# Altium schematic sheet MAIN_SHEET.SchDoc
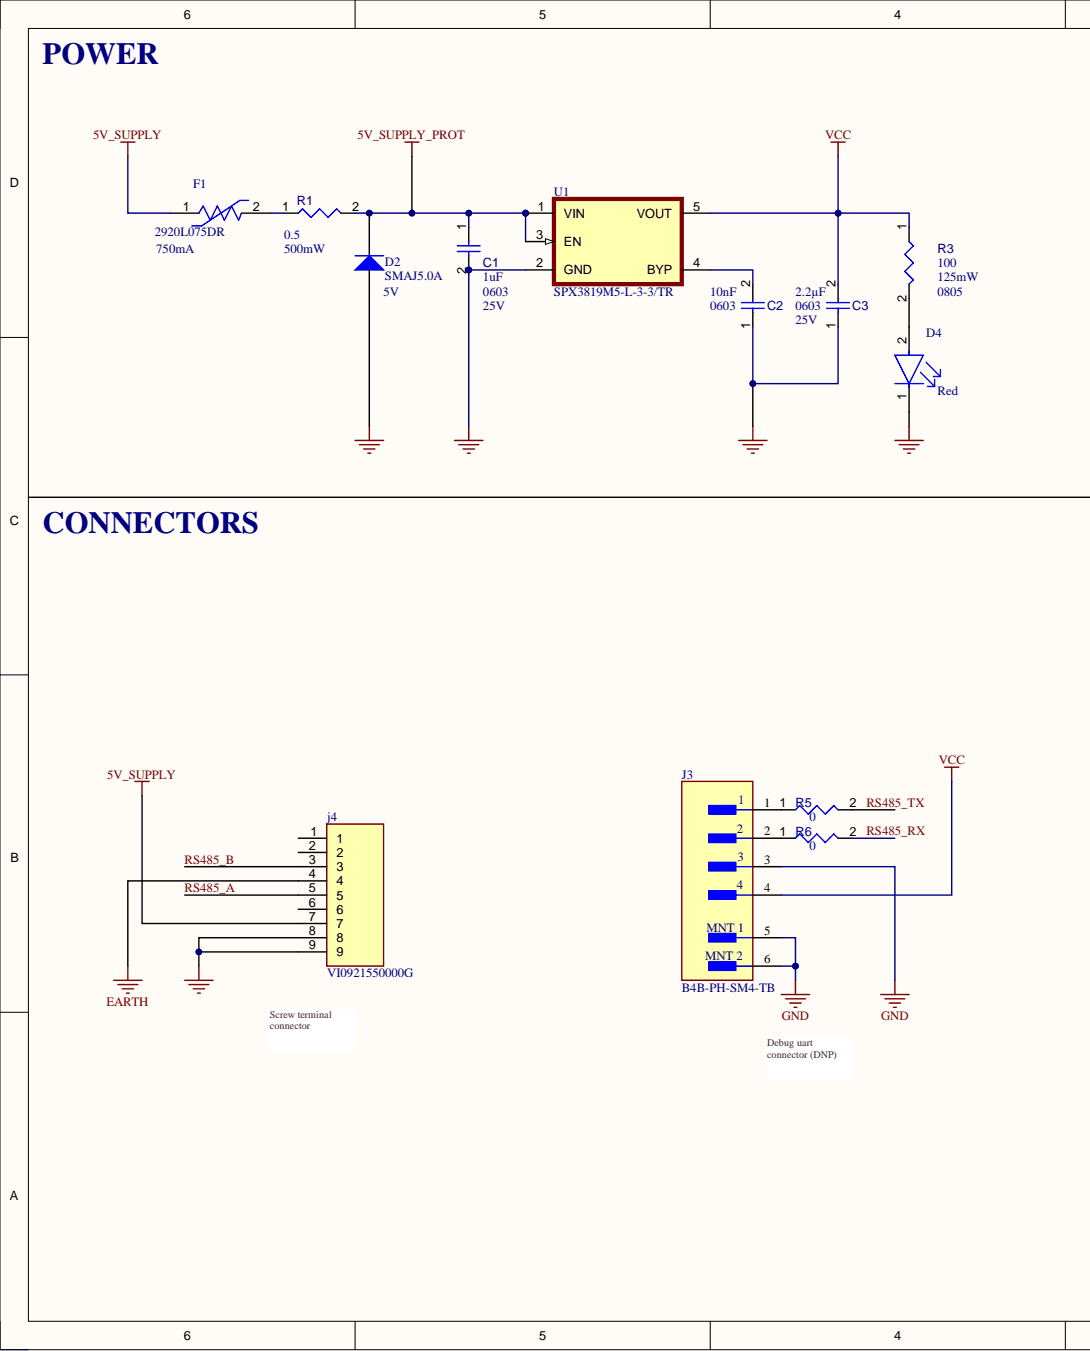
[diagram p0: MAIN_SHEET.SchDoc, left]
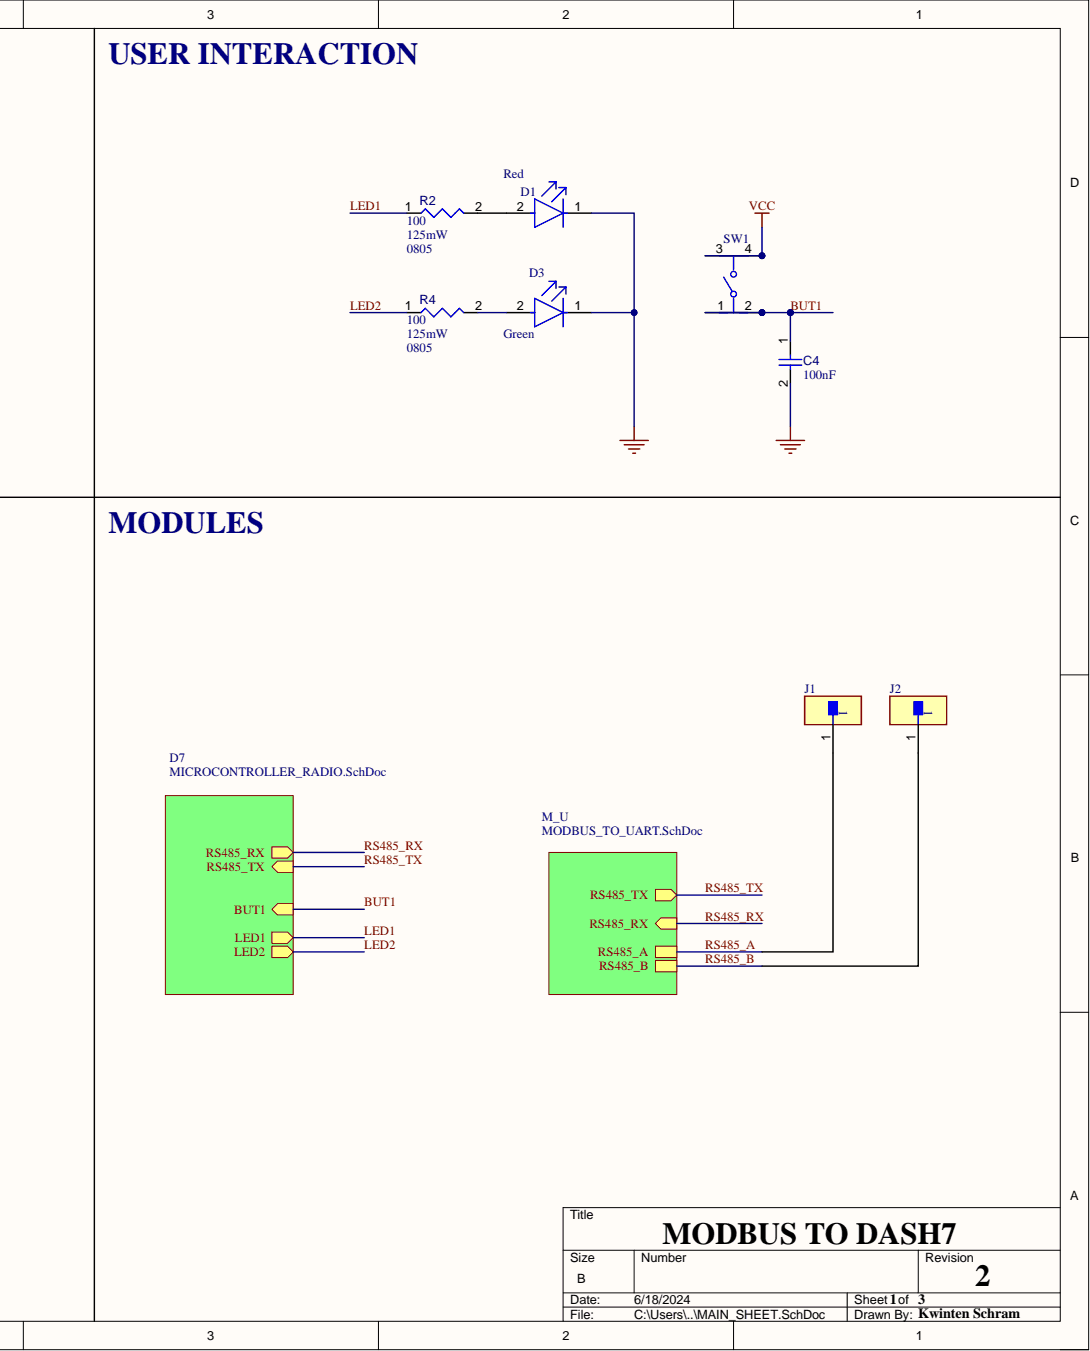
[diagram p1: MAIN_SHEET.SchDoc, right]

## Parts
C1  Capacitance: 1uF  Case/Package: 0603  Rated DC Voltage (URdc): 25V  [FP-CC0603-DA-MFG]  (hidden comment: CC0603KPX7R8BB105)
C2  value 10nF  Case/Package: 0603  [FP-C0603C-CF-IPC_B]  (hidden comment: C0603C103K2RACTU)
C3  value 2.2µF  Case/Package: 0603  Rated DC Voltage (URdc): 25V  [FP-GRM188-0_2-IPC_C]  (hidden comment: GRM188Z71E225KE43D)
C4  value 100nF  [FP-0603-L_1_6_0_15-W_0_81-MFG]  (hidden comment: 06033C104KAT2A)
D1  Color: Red  [FP-APT1608EC-MFG]  (hidden comment: APT1608SURCK)
D2  SMAJ5.0A  Forward Voltage: 5V  [BOUR-SMA-2_V]
D3  Color: Green  [FP-APG1608CGKC-T-MFG]  (hidden comment: APG1608CGKC/T)
D4  Color: Red  [FP-APT1608EC-MFG]  (hidden comment: APT1608SURCK)
F1  2920L075DR  Current Rating: 750mA  [FP-2920L050DR-MFG]
J1  PBC01SAAN  [FP-PBC01SAAN-MFG]  (value not drawn)
J2  PBC01SAAN  [FP-PBC01SAAN-MFG]  (value not drawn)
J3  B4B-PH-SM4-TB  [FP-B4B-PH-SM4-TB-MFG]
j4  VI0921550000G  [VI0921550000G-Footprint-1]
R1  value 0.5  Power: 500mW  [RESC3126X65X50NL10T20]  (hidden comment: RL1210FR-070R5L)
R2  value 100  Case/Package: 0805  Power: 125mW  [FP-AC0805-IPC_A]  (hidden comment: AC0805FR-07100RL)
R3  value 100  Case/Package: 0805  Power: 125mW  [FP-AC0805-IPC_A]  (hidden comment: AC0805FR-07100RL)
R4  value 100  Case/Package: 0805  Power: 125mW  [FP-AC0805-IPC_A]  (hidden comment: AC0805FR-07100RL)
R5  value 0  [FP-CRCW0402-e3-IPC_A]  (hidden comment: CRCW04020000Z0ED)
R6  value 0  [FP-CRCW0402-e3-IPC_A]  (hidden comment: CRCW04020000Z0ED)
SW1  PTS526SK15SMTR2LFS  [FP-PTS526SK15SMTR2LFS-MFG]  (value not drawn)
U1  SPX3819M5-L-3-3/TR  [SOT95P280X145-5N]

## Nets
5V_SUPPLY: F1.1 j4.7
5V_SUPPLY_PROT: C1.1 D2.2 R1.2 U1.1(VIN) U1.3(EN)
EARTH: j4.4
GND: C1.2 C2.1 C3.1 C4.2 D1.1 D2.1 D3.1 D4.1 J3.3 J3.5 J3.6 j4.8 j4.9 U1.2(GND)
VCC: C3.2 J3.4 R3.1 SW1.3 SW1.4 U1.5(VOUT)
BUT1: C4.1 SW1.1 SW1.2
LED1: R2.1
LED2: R4.1
RS485_A: J1.1 j4.5
RS485_B: J2.1 j4.3
RS485_RX: R6.2
RS485_TX: R5.2
NetC2_2: C2.2 U1.4(BYP)
NetD1_2: D1.2 R2.2
NetD3_2: D3.2 R4.2
NetD4_2: D4.2 R3.2
NetF1_2: F1.2 R1.1
NetJ3_1: J3.1 j4.1 j4.2 j4.6 R5.1
NetJ3_2: J3.2 R6.1

## Library data of the parts
C1 = CMP-001-00009-4: Ceramic Capacitor, Multilayer, Ceramic, 25V, 10% +Tol, 10% -Tol, X7R, 15% TC, 1uF, Surface Mount, 0603; Multilayer: Yes; Capacitor Type: Ceramic; Component Kind: Standard; Dielectric Material: Ceramic; Height: 0.8mm; JESD-609 Code: e3; Length: 1.6mm; Library Name: Altium Concord Pro on A365; Max Operating Temperature: 125°C; Min Operating Temperature: -55°C; Negative Tolerance: 10%; Number of Pins: 2; Package Style: SMTMeter; Packaging: Tape and Reel; Pins: 2; Positive Tolerance: 10%; REACH SVHC: Yes; RoHS Compliant: Yes; Size Code: 0603; Temperature Characteristics Code: X7R; Terminal Finish: Nickel; Tolerance: 10%; Voltage Rating: 25V; Width: 0.8mm
C2 = CMP-001-00038-1: Ceramic Capacitor, Multilayer, Ceramic, 200V, 10% +Tol, 10% -Tol, X7R, 15% TC, 0.01uF, Surface Mount, 0603; Multilayer: Yes; Capacitor Type: Ceramic; Component Kind: Standard; Dielectric Material: Ceramic; Height: 0.8mm; JESD-609 Code: e3; Length: 1.6mm; Library Name: Altium Concord Pro on A365; Max Operating Temperature: 125°C; Min Operating Temperature: -55°C; Mounting Technology: Surface Mount; Package Shape: Rectangular; Package Style: SMTMeter; Packaging: Tape and Reel; Pins: 2; Rated DC Voltage (URdc): 200V; Reach SVHC Compliant: Yes; RoHS Compliant: Yes; Temperature Characteristics Code: X7R; Terminal Finish: Nickel; Termination: Wraparound; Tolerance: 10%; Tolerance  (fill in as +-): 10%; Tolerance (fill in as +-): 10%; Width: 0.8mm
C3 = CMP-001-00039-1: Ceramic Capacitor, Multilayer, Ceramic, 25V, 10% +Tol, 10% -Tol, X7R, 15% TC, 2.2uF, Surface Mount, 0603; Multilayer: Yes; Capacitor Type: Ceramic; Component Kind: Standard; Dielectric Material: Ceramic; JESD-609 Code: e3; Library Name: Altium Concord Pro on A365; Max Operating Temperature: 125°C; Min Operating Temperature: -55°C; Mounting Technology: Surface Mount; Package Shape: Rectangular; Packaging: Tape and Reel; Pins: 2; Reach SVHC Compliant: Yes; RoHS Compliant: Yes; Temperature Characteristics Code: X7R; Terminal Finish: Nickel; Termination: Wraparound; Tolerance: 10%; Tolerance  (fill in as +-): 10%; Tolerance (fill in as +-): 10%
C4 = CMP-001-00020-3: Ceramic Capacitor, Multilayer, Ceramic, 25V, 10% +Tol, 10% -Tol, X7R, 15% TC, 0.1uF, Surface Mount, 0603; Multilayer: Yes; Capacitor Type: Ceramic; Case/Package: 0603; Component Kind: Standard; Dielectric Material: Ceramic; Height: 0.9mm; JESD-609 Code: e3; Length: 1.6mm; Library Name: Altium Concord Pro on A365; Max Operating Temperature: 125°C; Min Operating Temperature: -55°C; Mounting Technology: Surface Mount; Package Shape: Rectangular; Package Style: SMTMeter; Packaging: Tape and Reel; Pins: 2; Rated DC Voltage (URdc): 25V; Reach SVHC Compliant: Yes; RoHS Compliant: Yes; Temperature Characteristics Code: X7R; Terminal Finish: Nickel; Termination: Wraparound; Tolerance: 10%; Tolerance  (fill in as +-): 10%; Tolerance (fill in as +-): 10%; Width: 0.81mm
D1 D4 = CMP-007-00012-2: Single Color LED, Hyper Red, Water Clear, 1.2mm; Channels: 1; Forward Current: 30mA; Luminous Intensity-Nom: 150mcd; Overall Height: 0.75mm; Shape: Rectangular; Viewing Angle: 120°; Component Kind: Standard; Lens Style: WATER CLEAR; Library Name: Altium Concord Pro on A365; Max Operating Temperature: 85°C; Min Operating Temperature: -40°C; Mounting Technology: Surface Mount; Optoelectronic Device Type: SINGLE COLOR LED; Packaging: Tape and Reel; Pins: 2; Reach SVHC Compliant: Yes; RoHS Compliant: Yes; Size: 1.2mm; Terminal Pitch: 1.65mm
D2 = CMP-004-00017-1: Trans Voltage Suppressor Diode, 400W, 5V V(RWM), Unidirectional, 1 Element, Silicon, DO-214AC; Breakdown Voltage-Nom: 6.7V; Max Breakdown Voltage: 7V; Min Breakdown Voltage: 6.4V; Number of Elements: 1; Package Body Material: Plastic; Qualification Status: Not Qualified; Component Kind: Standard; Diode Element Material: SILICON; Diode Type: TRANS VOLTAGE SUPPRESSOR; JEDEC-95 Code: DO-214AC; JESD-30 Code: R-PDSO-C2; JESD-609 Code: e3; Library Name: Altium Concord Pro on A365; Max Operating Temperature: 150°C; Min Operating Temperature: -55°C; Moisture Sensitivity Level: 1; Non-rep Peak Rev Power Dis-Max: 400W; Package Shape: Rectangular; Package Style: SMALL OUTLINEMeter; Pins: 2; Polarity: Unidirectional; Reach SVHC Compliant: No; Rep Pk Reverse Voltage-Max: 5V; RoHS Compliant: Yes; Surface Mount: Yes; Technology: AVALANCHE; Terminal Finish: Tin; Terminal Form: C BEND; Terminal Position: DUAL
D3 = CMP-007-00010-1: Single Color LED, Green, Water Clear, 1.2mm; Channels: 1; Forward Current: 30mA; Luminous Intensity-Nom: 60mcd; Overall Height: 0.25mm; Shape: Rectangular; Viewing Angle: 120°; Component Kind: Standard; Lens Style: WATER CLEAR; Library Name: Altium Concord Pro on A365; Max Operating Temperature: 85°C; Min Operating Temperature: -40°C; Mounting Technology: Surface Mount; Optoelectronic Device Type: SINGLE COLOR LED; Packaging: Tape and Reel; Pins: 2; RoHS Compliant: Yes; Size: 1.2mm; Terminal Pitch: 1.65mm
F1 = CMP-005-00008-1: PTC Thermistor, 30V, 0.35ohm, Surface Mount; Package Height: 1.25mm; Package Length: 7.98mm; Package Width: 5.44mm; Reference Standard: UL; Thermistor Application: OVERLOAD PROTECTION; Value: 1R; Component Kind: Standard; JESD-609 Code: e3; Library Name: Altium Concord Pro on A365; Max Operating Temperature: 85°C; Min Operating Temperature: -40°C; Mounting Technology: Surface Mount; Package Shape: Rectangular; Packaging: Tape and Reel; Pins: 2; Reach SVHC Compliant: Yes; Resistor Type: PTC RESETTABLE FUSE; RoHS Compliant: Yes; Terminal Finish: Tin; Terminal Placement: Dual Ended; Termination: Wraparound; Working Voltage: 30V
J1 J2 = CMP-002-00033-2: Board Connector, 1 Contact(s), 1 Row(s), Male, Straight, 0.1 inch Pitch, Solder Terminal; Contact Pattern: RECTANGULAR; Contact Style: SQ PIN-SKT; Number Of Connectors: 1; Plating Thickness: 10uin; Rated Current (Signal): 3A; Reference Standard: UL; Reliability: COMMERCIAL; Body Breadth: 0.1inch; Body Depth: 0.1inch; Body Length: 0.1inch; Component Kind: Standard; Connector Type: BOARD CONNECTOR; Contact Finish - Mating: AU ON NI; Contact Finish - Termination: Matte Tin (Sn) - with Nickel (Ni) barrier; Contact Gender: Male; Contact Material: Bronze; Insulation Material: Polybutylene; JESD-609 Code: e3; Library Name: Altium Concord Pro on A365; Manufacturer Series: PBC01SAAN; Mating Contact Pitch: 0.1inch; Max Operating Temperature: 125°C; Min Operating Temperature: -65°C; Mounting Style: STRAIGHT; Mounting Technology: Board; Number of Contacts: 1; Number Of PCB Rows: 1; Number of Rows Loaded: 1; Reach SVHC Compliant: Yes; RoHS Compliant: Yes; Terminal Length: 0.12inch; Terminal Pitch: 2.54mm; Termination: Solder
J3 = CMP-002-00008-1: Header B4B-PH-SM4-TB, 2.00mm, 4-contacts, top entry, SMT /1000/reel; Component Kind: Standard; Library Name: Altium Concord Pro on A365
j4 = CMP-002-00032-2: Barrier Strip Terminal Block; Component Kind: Standard; Library Name: Altium Concord Pro on A365; Reach SVHC Compliant: Yes; RoHS Compliant: Yes; Terminal and Terminal Block Type: BARRIER STRIP TERMINAL BLOCK
R1 = CMP-009-00086-1: Fixed Resistor, Metal Glaze/thick Film, 0.5W, 0.5ohm, 0.5V, 1% +/-Tol, 300ppm/Cel, Surface Mount, 1210; Manufacturer Series: RL; Additional Feature: LASER TRIMMABLE; Package Height: 0.55mm; Package Length: 3.1mm; Package Width: 2.6mm; Rated Temperature: 70°C; Temperature Coefficient: 300ppm/°C; Case/Package: 1210; Component Kind: Standard; Construction: Chip; JESD-609 Code: e3; Library Name: Altium Concord Pro on A365; Max Operating Temperature: 125°C; Min Operating Temperature: -55°C; Mounting Technology: Surface Mount; Package Shape: Rectangular; Package Style: SMTMeter; Packaging: Tape and Reel; Pins: 2; Reach SVHC Compliant: Yes; Resistor Type: FIXED RESISTOR; RoHS Compliant: Yes; Series: RL; Technology: METAL GLAZE/THICK FILM; Terminal Finish: Nickel; Termination: Wraparound; Tolerance: 1%
R2-R4 = CMP-009-00088-1: Fixed Resistor, Metal Glaze/thick Film, 0.125W, 100ohm, 150V, 1% +/-Tol, 100ppm/Cel, Surface Mount, 0805; Additional Feature: LASER TRIMMABLE; Package Height: 0.5mm; Package Length: 2mm; Package Width: 1.25mm; Rated Temperature: 70°C; Reference Standard: AEC-Q200; Temperature Coefficient: 100ppm/°C; Component Kind: Standard; Construction: Chip; JESD-609 Code: e3; Library Name: Altium Concord Pro on A365; Max Operating Temperature: 155°C; Min Operating Temperature: -55°C; Mounting Technology: Surface Mount; Package Shape: Rectangular; Package Style: SMTMeter; Packaging: Tape and Reel; Pins: 2; Reach SVHC Compliant: Yes; Resistor Type: FIXED RESISTOR; RoHS Compliant: Yes; Technology: METAL GLAZE/THICK FILM; Terminal Finish: Nickel; Termination: Wraparound; Tolerance: 1%; Working Voltage: 150V
R5 R6 = CMP-009-00087-1: Metal Glaze/thick Film, 0.063W, 0ohm, Surface Mount, 0402; Package Height: 0.35mm; Package Length: 1mm; Package Width: 0.5mm; Power: 63mW; Rated Temperature: 70°C; Reference Standard: AEC-Q200; Case/Package: 0402; Component Kind: Standard; Construction: Chip; JESD-609 Code: e3; Library Name: Altium Concord Pro on A365; Max Operating Temperature: 155°C; Min Operating Temperature: -55°C; Mounting Technology: Surface Mount; Package Shape: Rectangular; Package Style: SMTMeter; Packaging: Tape and Reel; Pins: 2; Reach SVHC Compliant: Yes; Resistor Type: FIXED RESISTOR; RoHS Compliant: Yes; Technology: METAL GLAZE/THICK FILM; Terminal Finish: Nickel; Termination: Wraparound
SW1 = CMP-10040-000013-1: TACT 5.2 X 5.2, 1.5 MM H, 260GF,; Manufacturer: C&K; Contact Current Rating: 50mA; Contact Resistance: 100mΩ; Electromechanical Life: 200000Cycles; Operating Force: 250gf; Standoff Height: 0mm; Throw Configuration: SPST-NO; Voltage Rating Dc: 12V; Automotive: No; Category: Switch; Component Kind: Standard; ComponentLink2Description: Datasheet; ComponentLink2URL: https://www.ckswitches.com/media/2780/pts526.pdf; Device Class L1: Electromechanical; Device Class L2: Switches; Device Class L3: Tactile Switches; Digikey Description: TACT 5.2 X 5.2, 1.5 MM H, 260GF,; Height: 1.65mm; Lead Free: Yes; Library Name: Altium Content Vault; Manufacturer Part Number: PTS526SK15SMTR2LFS; Octopart: https://octopart.com/pts526+sk15+smtr2+lfs-c%26k+components-100617999; Package: PTS526SK15SMTR2LFS; RoHS: TRUE; Temperature: -20°C to +70°C; Temperature Range High: +70°C; Temperature Range Low: -20°C
U1 = CMP-031-00000-4: Fixed Positive LDO Regulator, 3.3V, 0.7V Dropout, PDSO5; Channels: 1; Dropout Voltage1-Max: 700mV; Max Supply Voltage: 16V; MF: MaxLinear, Inc.; Min Input Voltage: 2.5V; Output Current1-Max: 500mA; Output Voltage1-Max: 3.333V; Output Voltage1-Min: 3.267V; Package Body Material: Plastic; Qualification Status: Not Qualified; Regulator Type: FIXED POSITIVE SINGLE OUTPUT LDO REGULATOR; Time @ Peak Reflow Temperature-Max (s): 40s; Case/Package: LSSOP; Check_prices: https://www.snapeda.com/parts/SPX3819M5-L-3-3/TR/MaxLinear,%20Inc./view-part/35586/?ref=eda; Component Kind: Standard; Component Type: Standard; Design Item ID: SPX3819M5-L-3-3; Digi-Key_Part_Number: 1016-1873-1-ND; Height - Seated (Max): 1.45mm; JESD-30 Code: R-PDSO-G5; JESD-609 Code: e3; Length: 2.9mm; Library Name: Altium Concord Pro on A365; Moisture Sensitivity Level: 1; MP: SPX3819M5-L-3-3/TR; Operating Temperature, TJ-Max: 125°C; Operating Temperature, TJ-Min: -40°C; Output Voltage1-Nom: 3.3V; Package Shape: Rectangular; Package Style: SMALL OUTLINE, LOW PROFILE, SHRINK PITCHMeter; Peak Reflow Temperature (Cel): 260°C; Pins: 5; Purchase-URL: https://pricing.snapeda.com/search/part/SPX3819M5-L-3-3/TR/?ref=eda; Reach SVHC Compliant: Yes; RoHS Compliant: Yes; SnapEDA_Link: https://www.snapeda.com/parts/SPX3819M5-L-3-3/TR/MaxLinear,%20Inc./view-part/35586/?ref=snap; Source: SPX3819M5-L-3-3.SchLib; Surface Mount: Yes; Terminal Finish: Tin; Terminal Form: Gull Wing; Terminal Pitch: 0.95mm; Terminal Position: DUAL; Width: 1.6mm
